# Altium schematic sheet D-DW3210.SchDoc
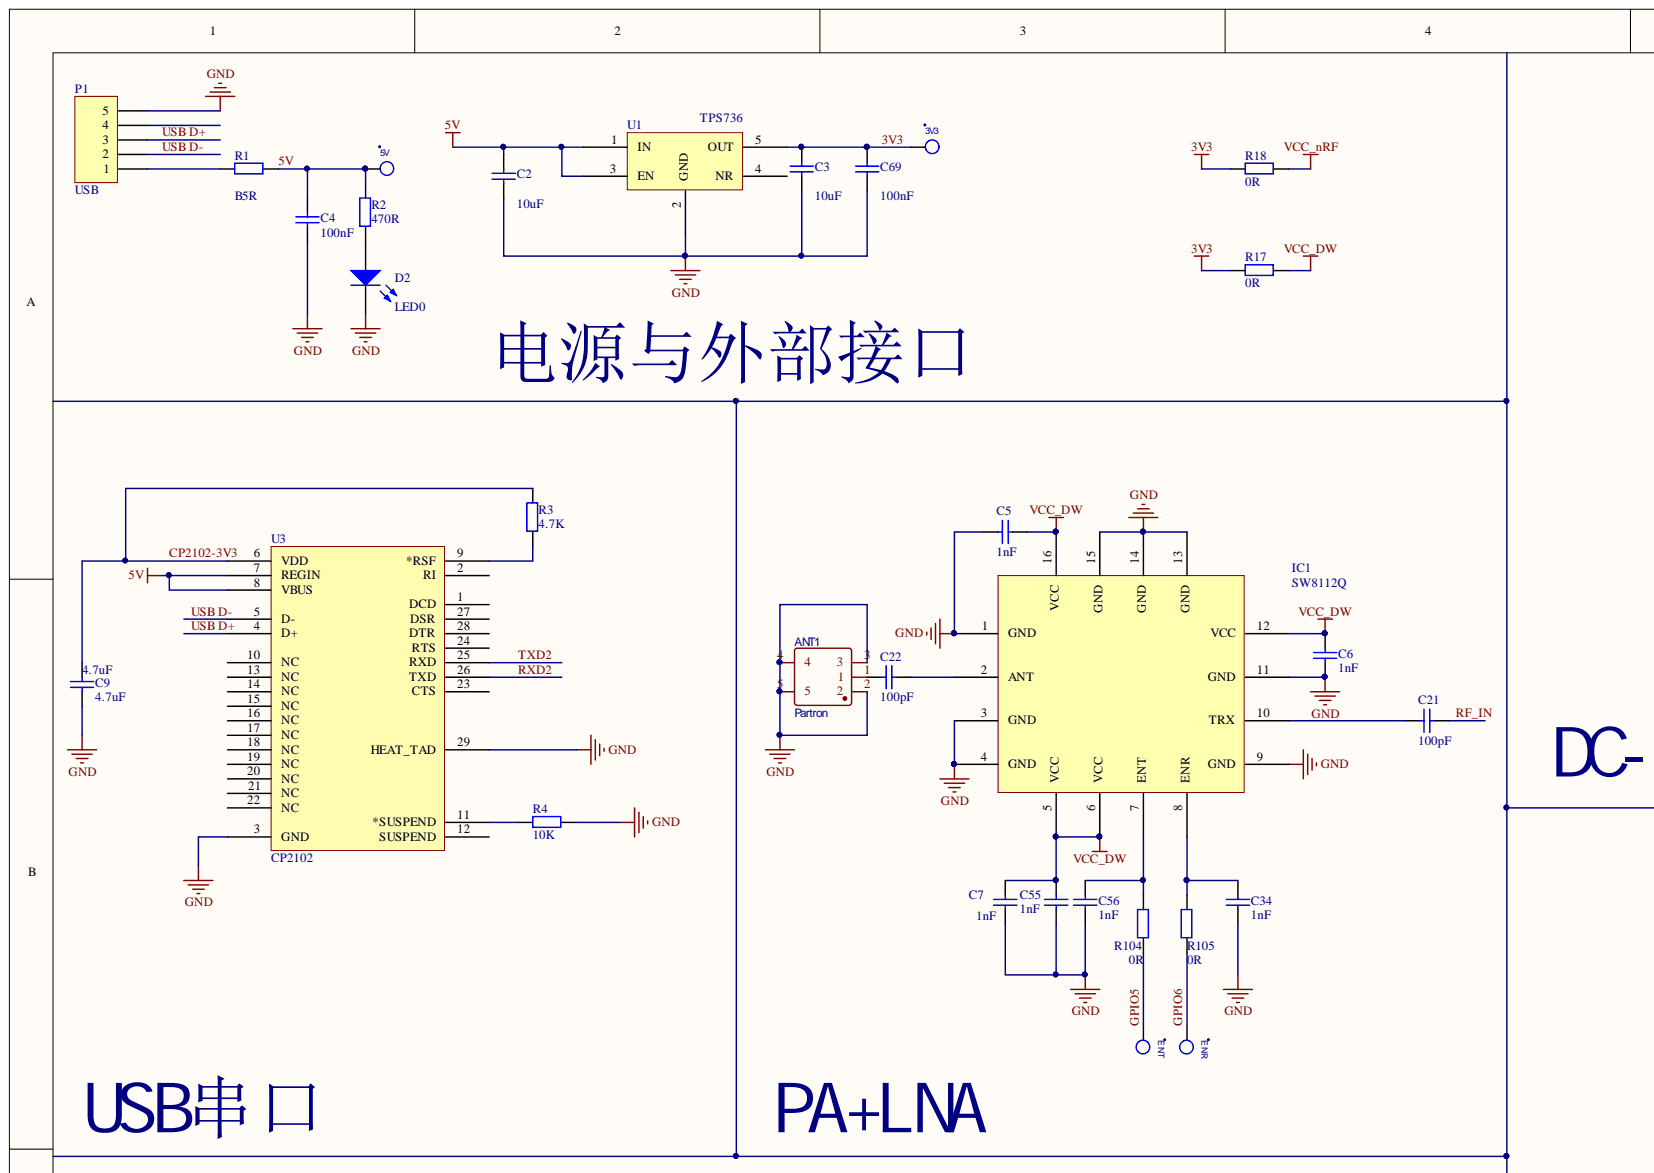
[diagram p0: D-DW3210.SchDoc, top left]
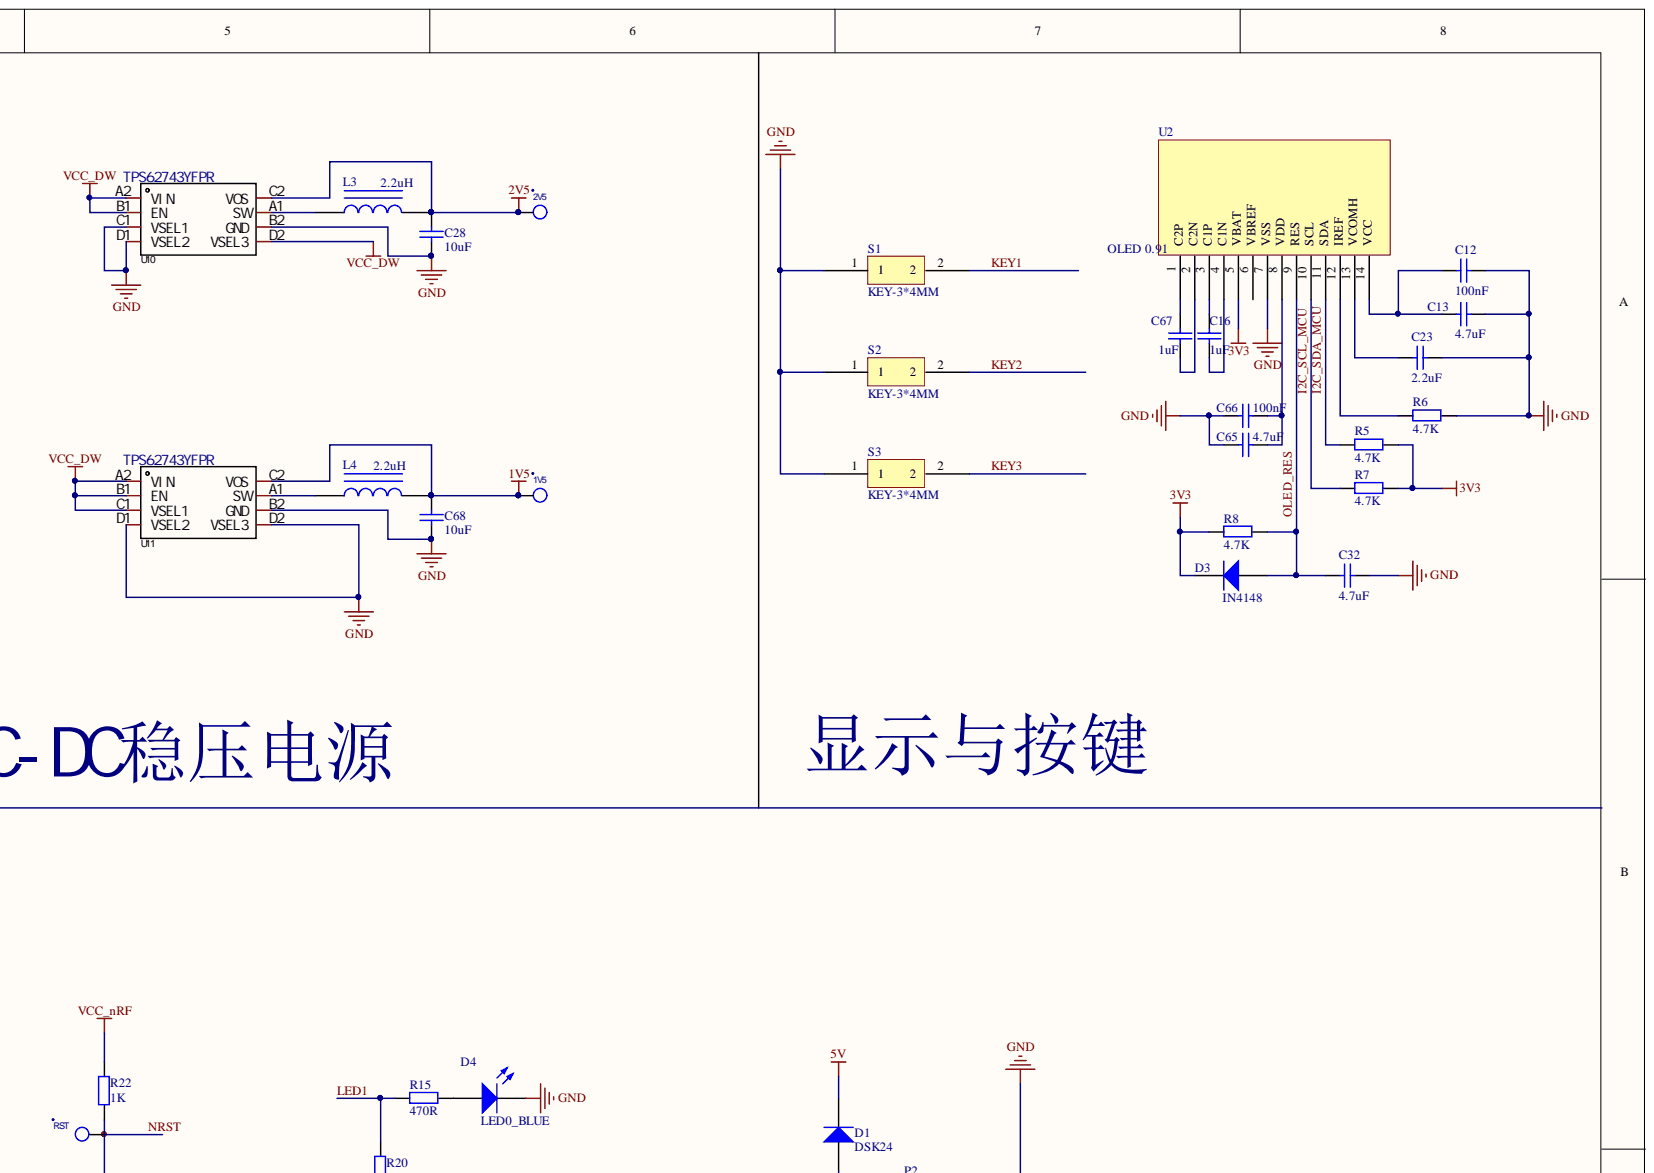
[diagram p1: D-DW3210.SchDoc, top right]
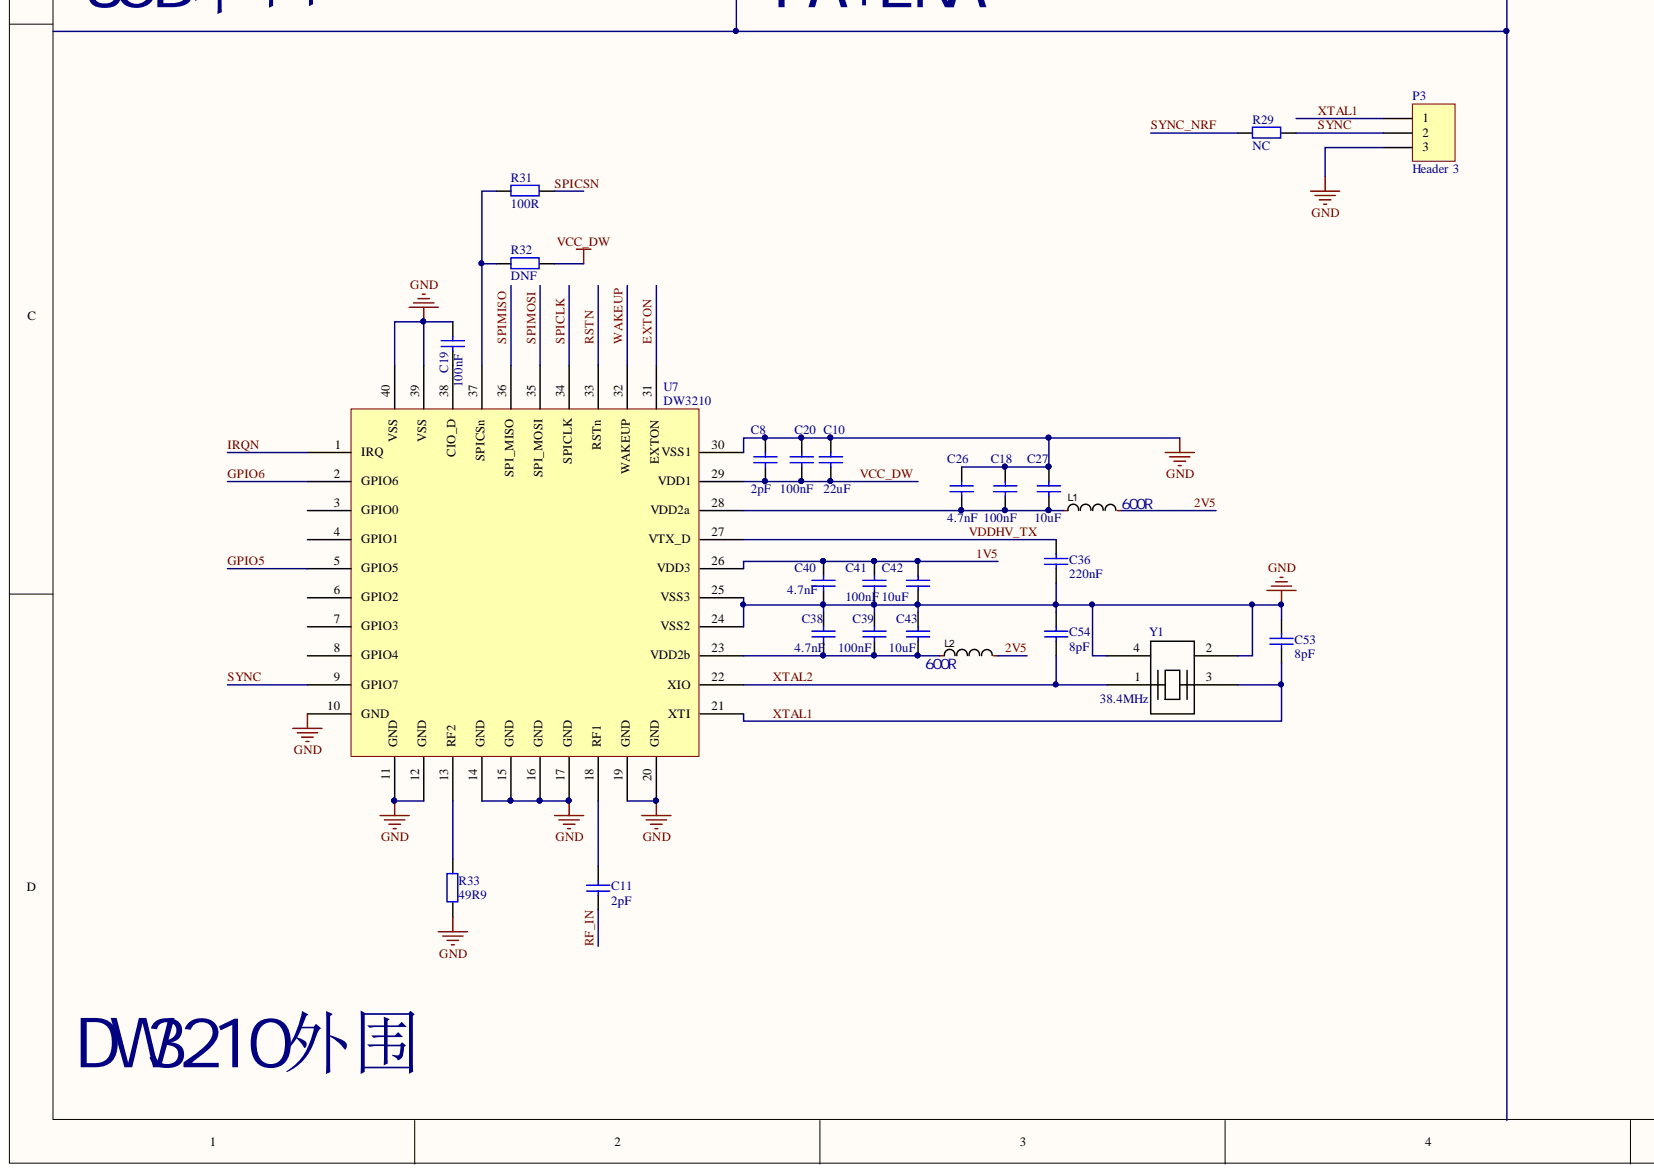
[diagram p2: D-DW3210.SchDoc, bottom left]
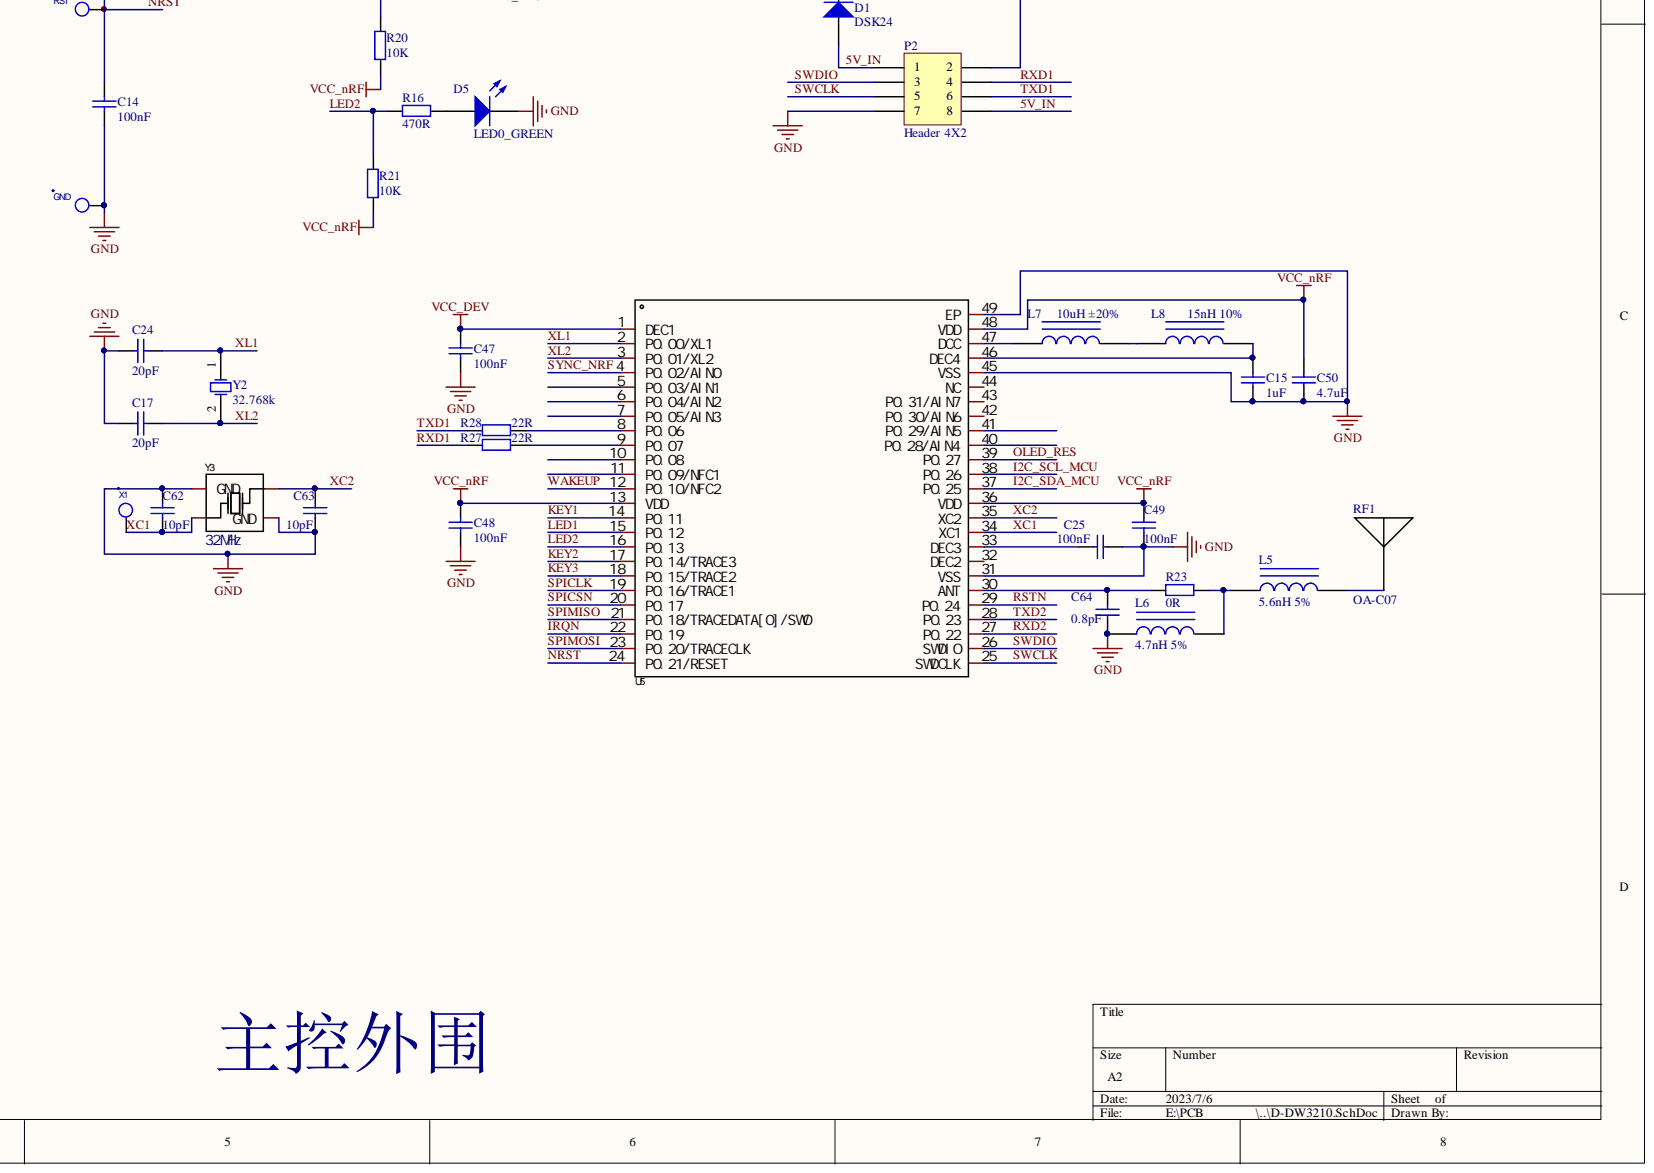
[diagram p3: D-DW3210.SchDoc, bottom right]

## Parts
1V5  TP_SMD_CIRCULAR_40MIL  [TP_40C]  (value not drawn)
2V5  TP_SMD_CIRCULAR_40MIL  [TP_40C]  (value not drawn)
3V3  TP_SMD_CIRCULAR_40MIL  [TP_40C]  (value not drawn)
5V  TP_SMD_CIRCULAR_40MIL  [TP_40C]  (value not drawn)
ANT1  Partron  [SMA]
C2  10uF  [0402_C]
C3  10uF  [0402_C]
C4  100nF  [0402_C]
C5  1nF  [0402_C]
C6  1nF  [0402_C]
C7  1nF  [0402_C]
C8  2pF  [0402_C]
C9  4.7uF  [0402_C]
C10  22uF  [0402_C]
C11  2pF  [0402_C]
C12  100nF  [0402_C]
C13  4.7uF  [0402_C]
C14  100nF  [0402_C]
C15  1uF  [0402_C]
C16  1uF  [0402_C]
C17  20pF  [0402_C]
C18  100nF  [0402_C]
C19  100nF  [0402_C]
C20  100nF  [0402_C]
C21  100pF  [0402_C]
C22  100pF  [0402_C]
C23  2.2uF  [0402_C]
C24  20pF  [0402_C]
C25  100nF  [0402_C]
C26  4.7nF  [0402_C]
C27  10uF  [0402_C]
C28  10uF  [0402_C]
C32  4.7uF  [0402_C]
C34  1nF  [0402_C]
C36  220nF  [0402_C]
C38  4.7nF  [0402_C]
C39  100nF  [0402_C]
C40  4.7nF  [0402_C]
C41  100nF  [0402_C]
C42  10uF  [0402_C]
C43  10uF  [0402_C]
C47  100nF  [0402_C]
C48  100nF  [0402_C]
C49  100nF  [0402_C]
C50  4.7uF  [0402_C]
C53  8pF  [0402_C]
C54  8pF  [0402_C]
C55  1nF  [0402_C]
C56  1nF  [0402_C]
C62  10pF  [0402_C]
C63  10pF  [0402_C]
C64  0.8pF  [0402_C]
C65  4.7uF  [0402_C]
C66  100nF  [0402_C]
C67  1uF  [0402_C]
C68  10uF  [0402_C]
C69  100nF  [0402_C]
D1  DSK24  [SOD-123]
D2  LED0  [LED0603 -++]
D3  IN4148  [SOD-123]
D4  LED0_BLUE  [LED0603 -++]
D5  LED0_GREEN  [LED0603 -++]
ENR  TP_SMD_CIRCULAR_40MIL  [TP_40C]  (value not drawn)
ENT  TP_SMD_CIRCULAR_40MIL  [TP_40C]  (value not drawn)
GND  TP_SMD_CIRCULAR_40MIL  [TP_40C]  (value not drawn)
IC1  SW8112Q  [QFN3X3-16-1]
L1  600R  [0402_L]
L2  600R  [0402_L]
L3  2.2uH  [0805_L]
L4  2.2uH  [0805_L]
L5  5.6nH 5%  [0402_L]
L6  4.7nH 5%  [0402_L]
L7  10uH ±20%  [0603_L]
L8  15nH 10%  [0402_L]
P1  USB  [USB 5IN]
P2  Header 4X2  [HDR2X4]
P3  Header 3  [HDR1X3]
R1  B5R  [0805]
R2  470R  [0402_R]
R3  4.7K  [0402_R]
R4  10K  [0402_R]
R5  4.7K  [0402_R]
R6  4.7K  [0402_R]
R7  4.7K  [0402_R]
R8  4.7K  [0402_R]
R15  470R  [0402_R]
R16  470R  [0402_R]
R17  0R  [0402_R]
R18  0R  [0402_R]
R20  10K  [0402_R]
R21  10K  [0402_R]
R22  1K  [0402_R]
R23  0R  [0402_R]
R27  22R  [0402_R]
R28  22R  [0402_R]
R29  NC  [0402_R]
R31  100R  [0402_R]
R32  DNF  [0402_R]
R33  49R9  [0402_R]
R104  0R  [0402_R]
R105  0R  [0402_R]
RF1  OA-C07  [OA-C07]
RST  TP_SMD_CIRCULAR_40MIL  [TP_40C]  (value not drawn)
S1  KEY-3*4MM  [KEY-3*4MM]
S2  KEY-3*4MM  [KEY-3*4MM]
S3  KEY-3*4MM  [KEY-3*4MM]
U1  TPS736  [SOT-23-5]
U2  OLED 0.91  [OLED 0.91]
U3  CP2102  [CP2102]
U5  NRF52832-QFAA-R  [QFN-48_6*6]  (value not drawn)
U7  DW3210  [DW3000]
U10  TPS62743YFPR  [TPS62743YFPR]
U11  TPS62743YFPR  [TPS62743YFPR]
X1  TP_SMD_CIRCULAR_40MIL  [TP_40C]  (value not drawn)
Y1  38.4MHz  [2016]
Y2  32.768k  [XTAL_SMD_3.2X1.5MM]
Y3  32MHz  [1612_4P]

## Nets
GND: ANT1.2 ANT1.3 ANT1.4 ANT1.5 C2.1 C3.1 C4.1 C5.2 C6.1 C7.1 C8.2 C9.1 C10.2 C12.1 C13.1 C14.2 C15.1 C17.2 C18.2 C19.2 C20.2 C23.2 C24.2 C25.2 C26.2 C27.2 C28.2 C32.2 C34.1 C36.2 C38.2 C39.2 C40.1 C41.1 C42.1 C43.2 C47.2 C48.2 C49.2 C50.1 C53.2 C54.2 C55.2 C56.1 C62.1 C63.2 C64.2 C65.2 C66.2 C68.2 C69.1 D2.2 D4.2 D5.2 GND.1 IC1.1(GND) IC1.3(GND) IC1.4(GND) IC1.9(GND) IC1.11(GND) IC1.13(GND) IC1.14(GND) IC1.15(GND) IC1.17 L6.1 P1.5 P2.2 P2.7 P3.3 R4.1 R6.2 R33.1 S1.1 S2.1 S3.1 U1.2(GND) U2.7(VSS) U3.3(GND) U3.29(HEAT_TAD) U5.31(VSS) U5.45(VSS) U5.49(EP) U7.10(GND) U7.11(GND) U7.12(GND) U7.14(GND) U7.15(GND) U7.16(GND) U7.17(GND) U7.19(GND) U7.20(GND) U7.24(VSS2) U7.25(VSS3) U7.30(VSS1) U7.39(VSS) U7.40(VSS) U10.B2(GND) U10.C1(VSEL1) U10.D1(VSEL2) U11.B2(GND) U11.D1(VSEL2) U11.D2(VSEL3) Y1.2 Y1.4 Y3.2(GND) Y3.4(GND)
VCC_DEV: C47.1 U5.1(DEC1)
VCC_nRF: C48.1 C49.1 C50.2 R18.1 R20.1 R21.1 R22.2 U5.13(VDD) U5.36(VDD) U5.48(VDD)
1V5: 1V5.1 C40.2 C41.2 C42.2 C68.1 L4.2 U7.26(VDD3) U11.C2(VOS)
2V5: 2V5.1 C28.1 L1.2 L2.2 L3.2 U10.C2(VOS)
3V3: 3V3.1 C3.2 C69.2 D3.2 R5.2 R7.2 R8.2 R17.2 R18.2 U1.5(OUT) U2.5(VBAT)
5V: 5V.1 C2.2 C4.2 D1.2 R1.1 R2.2 U1.1(IN) U1.3(EN) U3.7(REGIN) U3.8(VBUS)
5V_IN: D1.1 P2.1 P2.8
CP2102-3V3: C9.2 R3.1 U3.6(VDD)
EXTON: U7.31(EXTON)
GPIO5: ENT.1 R104.1 U7.5(GPIO5)
GPIO6: ENR.1 R105.1 U7.2(GPIO6)
I2C_SCL_MCU: R7.1 U2.10(SCL) U5.38(P0.26)
I2C_SDA_MCU: R5.1 U2.11(SDA) U5.37(P0.25)
IRQN: U5.22(P0.19) U7.1(IRQ)
KEY1: S1.2 U5.14(P0.11)
KEY2: S2.2 U5.17(P0.14/TRACE3)
KEY3: S3.2 U5.18(P0.15/TRACE2)
LED1: R15.2 R20.2 U5.15(P0.12)
LED2: R16.2 R21.2 U5.16(P0.13)
NRST: C14.1 R22.1 RST.1 U5.24(P0.21/RESET)
OLED_RES: C32.1 D3.1 R8.1 U2.9(RES) U5.39(P0.27)
RF_IN: C11.1 C21.1
RSTN: U5.29(P0.24) U7.33(RSTn)
RXD1: P2.4 R27.1
RXD2: U3.26(TXD) U5.27(P0.22)
SPICLK: U5.19(P0.16/TRACE1) U7.34(SPICLK)
SPICSN: R31.1 U5.20(P0.17)
SPIMISO: U5.21(P0.18/TRACEDATA[0]/SWO) U7.36(SPI_MISO)
SPIMOSI: U5.23(P0.20/TRACECLK) U7.35(SPI_MOSI)
SWCLK: P2.5 U5.25(SWDCLK)
SWDIO: P2.3 U5.26(SWDIO)
SYNC: P3.2 R29.1 U7.9(GPIO7)
SYNC_NRF: R29.2 U5.4(P0.02/AIN0)
TXD1: P2.6 R28.1
TXD2: U3.25(RXD) U5.28(P0.23)
USB D+: P1.3 U3.4(D+)
USB D-: P1.2 U3.5(D-)
VCC_DW: C5.1 C6.2 C7.2 C8.1 C10.1 C20.1 C55.1 IC1.5(VCC) IC1.6(VCC) IC1.12(VCC) IC1.16(VCC) R17.1 R32.2 U7.29(VDD1) U10.A2(VIN) U10.B1(EN) U10.D2(VSEL3) U11.A2(VIN) U11.B1(EN) U11.C1(VSEL1)
VDDHV_TX: C36.1 U7.27(VTX_D)
WAKEUP: U5.12(P0.10/NFC2) U7.32(WAKEUP)
XC1: C62.2 U5.34(XC1) X1.1 Y3.1
XC2: C63.1 U5.35(XC2) Y3.3
XL1: C24.1 U5.2(P0.00/XL1) Y2.1
XL2: C17.1 U5.3(P0.01/XL2) Y2.2
XTAL1: C53.1 P3.1 U7.21(XTI) Y1.3
XTAL2: C54.1 U7.22(XIO) Y1.1
NetANT1_1: ANT1.1 C22.2
NetC11_2: C11.2 U7.18(RF1)
NetC12_2: C12.2 C13.2 U2.14(VCC)
NetC15_2: C15.2 L8.2 U5.46(DEC4)
NetC16_1: C16.1 U2.4(C1N)
NetC16_2: C16.2 U2.3(C1P)
NetC18_1: C18.1 C26.1 C27.1 L1.1 U7.28(VDD2a)
NetC19_1: C19.1 U7.38(CIO_D)
NetC21_2: C21.2 IC1.10(TRX)
NetC22_1: C22.1 IC1.2(ANT)
NetC23_1: C23.1 U2.13(VCOMH)
NetC25_1: C25.1 U5.33(DEC3)
NetC34_2: C34.2 IC1.8(ENR) R105.2
NetC38_1: C38.1 C39.1 C43.1 L2.1 U7.23(VDD2b)
NetC56_2: C56.2 IC1.7(ENT) R104.2
NetC64_1: C64.1 R23.2 U5.30(ANT)
NetC65_1: C65.1 C66.1 U2.8(VDD)
NetC67_1: C67.1 U2.1(C2P)
NetC67_2: C67.2 U2.2(C2N)
NetD2_1: D2.1 R2.1
NetD4_1: D4.1 R15.1
NetD5_1: D5.1 R16.1
NetL3_1: L3.1 U10.A1(SW)
NetL4_1: L4.1 U11.A1(SW)
NetL5_1: L5.1 L6.2 R23.1
NetL5_2: L5.2 RF1.1
NetL7_1: L7.1 U5.47(DCC)
NetL7_2: L7.2 L8.1
NetP1_1: P1.1 R1.2
NetR3_2: R3.2 U3.9(*RSF)
NetR4_2: R4.2 U3.11(*SUSPEND)
NetR6_1: R6.1 U2.12(IREF)
NetR27_2: R27.2 U5.9(P0.07)
NetR28_2: R28.2 U5.8(P0.06)
NetR31_2: R31.2 R32.1 U7.37(SPICSn)
NetR33_2: R33.2 U7.13(RF2)
N00019:

Not connected: P1 4; U1 4(NR); U2 6(VBREF); U3 1(DCD) 2(RI) 10(NC) 12(SUSPEND) 13(NC) 14(NC) 15(NC) 16(NC) 17(NC) 18(NC) 19(NC) 20(NC) 21(NC) 22(NC) 23(CTS) 24(RTS) 27(DSR) 28(DTR); U5 5(P0.03/AIN1) 6(P0.04/AIN2) 7(P0.05/AIN3) 10(P0.08) 11(P0.09/NFC1) 32(DEC2) 40(P0.28/AIN4) 41(P0.29/AIN5) 42(P0.30/AIN6) 43(P0.31/AIN7) 44(NC); U7 3(GPIO0) 4(GPIO1) 6(GPIO2) 7(GPIO3) 8(GPIO4)

## Library data of the parts
1V5 2V5 3V3 5V ENR ENT GND RST X1 = TP_SMD_CIRCULAR_40MIL: Test Pad, SMD, Circular, 40mil; Assembly_Instruction: DNI; Source_Path: D:\PROJECTS\3D_STEREO_CAMERA\SCHEMATICS\LIBRARY\3D_STEREO_CAMERA_LIB.OLB; Component Kind: Standard; Package: SMD; PCB DECAL: TP_40C; Value: SMD
ANT1 = Partron: BOM_Manufacturer Part: ACS5200HFAUWB; Contributor: LCSC; nameAlias: Value; BOM_Manufacturer: Partron; BOM_Supplier: LCSC; BOM_Supplier Part: C224424; Component Kind: Standard; spicePre: L; spiceSymbolName: ACS5200HFAUWB
C2-C4 C9 C69 = Cap: Capacitor; Component Kind: Standard; LatestRevisionDate: 17-Jul-2002; LatestRevisionNote: Re-released for DXP Platform.; Library Name: Miscellaneous Devices.IntLib; PackageReference: RAD-0.3; Published: 8-Jun-2000; Signal Integrity: Cap; Simulation: CAP; Publisher: Altium Limited
  C2 C3: Value: 10uF
  C4: PackageDescription: Radial Cap, Thru-Hole; 2 Leads; 0.3 in Pin Spacing; Value: 100nF
  C9: PackageDescription: Radial Cap, Thru-Hole; 2 Leads; 0.3 in Pin Spacing; Value: 4.7uF
  C69: Value: 100nF
C5-C7 C21 C22 C34 C55 C56 = 0402 100pF (101) 5% 50V: 贴片电容; Component Kind: Standard; ComponentLink1Description: 供应商链接; ComponentLink1URL: http://www.szlcsc.com/product/details_1898.html; Library Name: Miscellaneous Devices LC.IntLib; Package: 0402; Supplier: LC; SuppliersPartNumber: C1546
C8 C10-C16 C18-C20 C23 C25-C28 C32 C36 C38-C43 C47-C50 C53 C54 C62-C68 = 0402 100nF (104) 10% 16V: 贴片电容; Component Kind: Standard; ComponentLink1Description: 供应商链接; ComponentLink1URL: http://www.szlcsc.com/product/details_1877.html; Library Name: Miscellaneous Devices LC.IntLib; Package: 0402; Supplier: LC; SuppliersPartNumber: C1525
C17 C24 = Cap: Capacitor; Value: 15pF; Component Kind: Standard; LatestRevisionDate: 17-Jul-2002; LatestRevisionNote: Re-released for DXP Platform.; Library Name: Miscellaneous Devices.IntLib; PackageReference: RAD-0.3; Published: 8-Jun-2000; Signal Integrity: Cap; Simulation: CAP; Publisher: Altium Limited
D1 = SMA(DO-214AC) M7: 二极管; Notepad: 1N4007 4007 编号C2464 已经停售, 使用新物料编号C95872; Component Kind: Standard; ComponentLink1Description: 供应商链接; ComponentLink1URL: http://www.szlcsc.com/product/details_2841.html; Library Name: Miscellaneous Devices LC.IntLib; Package: SMA(DO-214AC); Supplier: LC; SuppliersPartNumber: C95872
D2 D4 D5 = LED0: Typical INFRARED GaAs LED; Component Kind: Standard; LatestRevisionDate: 08-Jul-2005; LatestRevisionNote: Mech Layer 1 removed.; Library Name: Miscellaneous Devices.IntLib; PackageDescription: LED; 2 Leads; PackageReference: LED-0; Published: 8-Jun-2000; Simulation: LED0; Publisher: Altium Limited
D3 = SOD-123 B5819W  SL: 肖特基二极管; Component Kind: Standard; ComponentLink1Description: 供应商链接; ComponentLink1URL: http://www.szlcsc.com/product/details_9093.html; Library Name: Miscellaneous Devices LC.IntLib; Package: SOD-123; Supplier: LC; SuppliersPartNumber: C8598
L1 L2 = BLM15AG601SN1D: Manufacturer: muRata(村田); Add into BOM: yes; Circuits: 1; Current Rating: 300mA; DC Resistance: 520mΩ; Impedance @ Frequency: 600Ω@100MHz; Tolerance: ±25%; Component Kind: Standard; Convert to PCB: yes; Datasheet: https://atta.szlcsc.com/upload/public/pdf/source/20180326/C76884_359C8A51D4D88607B1C7C4355A0568B0.pdf; Device: BLM15AG601SN1D; JLCPCB Part Class: Extended Part; LCSC Part Name: 600Ω@100MHz ±25%; Manufacturer Part: BLM15AG601SN1D; Name: BLM15AG601SN1D; PCB3D: L0402_L1.0-W0.5-H0.5_BEAD; Supplier: LCSC; Supplier Footprint: 0402; Supplier Part: C76884; Symbol: BLM15AG601SN1D
L3 L4 = 0402 2.7nH 0.3nH: 贴片电感; Component Kind: Standard; ComponentLink1Description: 供应商链接; ComponentLink1URL: http://www.szlcsc.com/product/details_27872.html; Library Name: Miscellaneous Devices LC.IntLib; Package: 0402; Supplier: LC; SuppliersPartNumber: C27123
L5 L6 L8 = 0402 15nH 5%: 贴片电感; Component Kind: Standard; ComponentLink1Description: 供应商链接; ComponentLink1URL: http://www.szlcsc.com/product/details_27892.html; Library Name: Miscellaneous Devices LC.IntLib; Package: 0402; Supplier: LC; SuppliersPartNumber: C27143
L7 = 0603 10uH 10%: 贴片电感; Component Kind: Standard; ComponentLink1Description: 供应商链接; ComponentLink1URL: http://www.szlcsc.com/product/details_1387.html; Library Name: Miscellaneous Devices LC.IntLib; Package: 0603; Supplier: LC; SuppliersPartNumber: C1035
P1 = Header 5: Header, 5-Pin; Component Kind: Standard; LatestRevisionDate: 17-Jul-2002; LatestRevisionNote: Re-released for DXP Platform.; Library Name: Miscellaneous Connectors.IntLib; Signal Integrity: Connector; Publisher: Altium Limited
P2 = Header 4X2: Header, 4-Pin, Dual row; Component Kind: Standard; LatestRevisionDate: 17-Jul-2002; LatestRevisionNote: Re-released for DXP Platform.; Library Name: Miscellaneous Connectors.IntLib; Signal Integrity: Connector; Publisher: Altium Limited
P3 = Header 3: Header, 3-Pin; Component Kind: Standard; LatestRevisionDate: 17-Jul-2002; LatestRevisionNote: Re-released for DXP Platform.; Library Name: Miscellaneous Connectors.IntLib; Signal Integrity: Connector; Publisher: Altium Limited
R1 = Res2: Resistor; Component Kind: Standard; LatestRevisionDate: 17-Jul-2002; LatestRevisionNote: Re-released for DXP Platform.; Library Name: Miscellaneous Devices.IntLib; PackageDescription: Axial Device, Thru-Hole; 2 Leads; 0.4 in Pin Spacing; PackageReference: AXIAL-0.4; Published: 8-Jun-2000; Signal Integrity: Res; Simulation: RESISTOR; Value: B5R; Publisher: Altium Limited
R2-R8 R15-R18 R20-R23 R27-R29 R31-R33 = 0402 100KΩ (1003) 1%: 贴片电阻; Component Kind: Standard; ComponentLink1Description: 供应商链接; ComponentLink1URL: http://www.szlcsc.com/product/details_26484.html; Library Name: Miscellaneous Devices LC.IntLib; Package: 0402; Supplier: LC; SuppliersPartNumber: C25741
R104 R105 = 0402 10KΩ (1002) 1%: 贴片电阻; Component Kind: Standard; ComponentLink1Description: 供应商链接; ComponentLink1URL: http://www.szlcsc.com/product/details_26487.html; Library Name: Miscellaneous Devices LC.IntLib; Package: 0402; Supplier: LC; SuppliersPartNumber: C25744
U1 = TPS73633: Component Kind: Standard; Library Name: 封装.SchLib
U5 = NRF52832-QFAA-R: Manufacturer: NORDIC; Add into BOM: yes; Current Of Transmitting: 5.3mA; Data Rate: 2Mbps; Interface: I2C, SPI, UART; Operating Voltage: 1.7V~3.6V; Output Power: 4dBm; Receive Current: 5.4mA; Sensitivity: -96dBm; Typical Application Frequency: 2.4GHz; Applications: 蓝牙; Component Kind: Standard; Convert to PCB: yes; Datasheet: https://atta.szlcsc.com/upload/public/pdf/source/20191122/C77540_B22384C9419DF4416C7889F27174782C.pdf; Device: NRF52832-QFAA-R; Frequency Range: -; JLCPCB Part Class: Extended Part; LCSC Part Name: nRF52832-QFAA-R; Manufacturer Part: NRF52832-QFAA-R; Name: NRF52832-QFAA-R; Operating Temperature: -40℃~+85℃; PCB3D: QFN-48_L6.0-W6.0-P0.40-BL-EP3.8; Supplier: LCSC; Supplier Footprint: QFN-48(6x6); Supplier Part: C77540; Symbol: NRF52832-QFAA-R
U10 U11 = TPS62743YFPR: Manufacturer: TI(德州仪器); Add into BOM: yes; Function: 降压型; Component Kind: Standard; Convert to PCB: yes; Datasheet: https://atta.szlcsc.com/upload/public/pdf/source/20190325/C374070_C5DA5DCD33E7C336BFAA53CE94623451.pdf; Device: TPS62743YFPR; Input Voltage: -; JLCPCB Part Class: Extended Part; LCSC Part Name: 降压型; Manufacturer Part: TPS62743YFPR; Name: TPS62743YFPR; Number of Outputs: -; Output Current (Max): -; PCB3D: DSBGA-8_L1.6-W0.9-H0.5-R2-C4-P0.40-BL; Supplier: LCSC; Supplier Footprint: DSBGA-8; Supplier Part: C374070; Switching Frequency: -; Symbol: TPS62743YFPR; Synchronous Rectification: -; Topology: -
Y1 = RSX-10: Component Kind: Standard; Library Name: DWM1000.SchLib
Y2 = XTAL: Crystal Oscillator; Component Kind: Standard; LatestRevisionDate: 17-Jul-2002; LatestRevisionNote: Re-released for DXP Platform.; Library Name: Miscellaneous Devices.IntLib; PackageReference: R38; Published: 8-Jun-2000; Simulation: XTAL; Publisher: Altium Limited
Y3 = Q22FA1280001800: Manufacturer: EPSON(爱普生); Add into BOM: yes; Crystal Type: 贴片无源晶振; Equivalent Series Resistance (ESR): 60Ω; Frequency Tolerance: ±10ppm; Load Capacitance: 12pF; Component Kind: Standard; Convert to PCB: yes; Datasheet: https://atta.szlcsc.com/upload/public/pdf/source/20180822/C255896_55DA51235662C930BAF12C67D4AE59BB.pdf; Device: Q22FA1280001800; Frequency Stability: -; JLCPCB Part Class: Extended Part; LCSC Part Name: FA-128 32.000000MHz 12pF ±10ppm; Manufacturer Part: Q22FA1280001800; Name: 32MHz; Operating Temperature: -40℃~+85℃; PCB3D: OSC-SMD_4P-L2.0-W1.6-H0.8; Supplier: LCSC; Supplier Footprint: SMD2016-4P; Supplier Part: C255896; Symbol: Q22FA1280001800; Value: 32MHz
IC1 = SW8112Q: Component Kind: Standard; Library Name: D-DWM.SchLib
RF1 = OA-C07: Component Kind: Standard; Library Name: D-DWM.SchLib
S1-S3 = KEY-3*4MM: Component Kind: Standard; Library Name: DWM1000.SchLib
U2 = OLED 0.91: Component Kind: Standard; Library Name: DWM1000.SchLib
U3 = CP2102: Component Kind: Standard; Library Name: 封装.SchLib
U7 = DW3210: Component Kind: Standard; Library Name: D-DWM.SchLib
